# Altium schematic sheet AI驱动.SchDoc
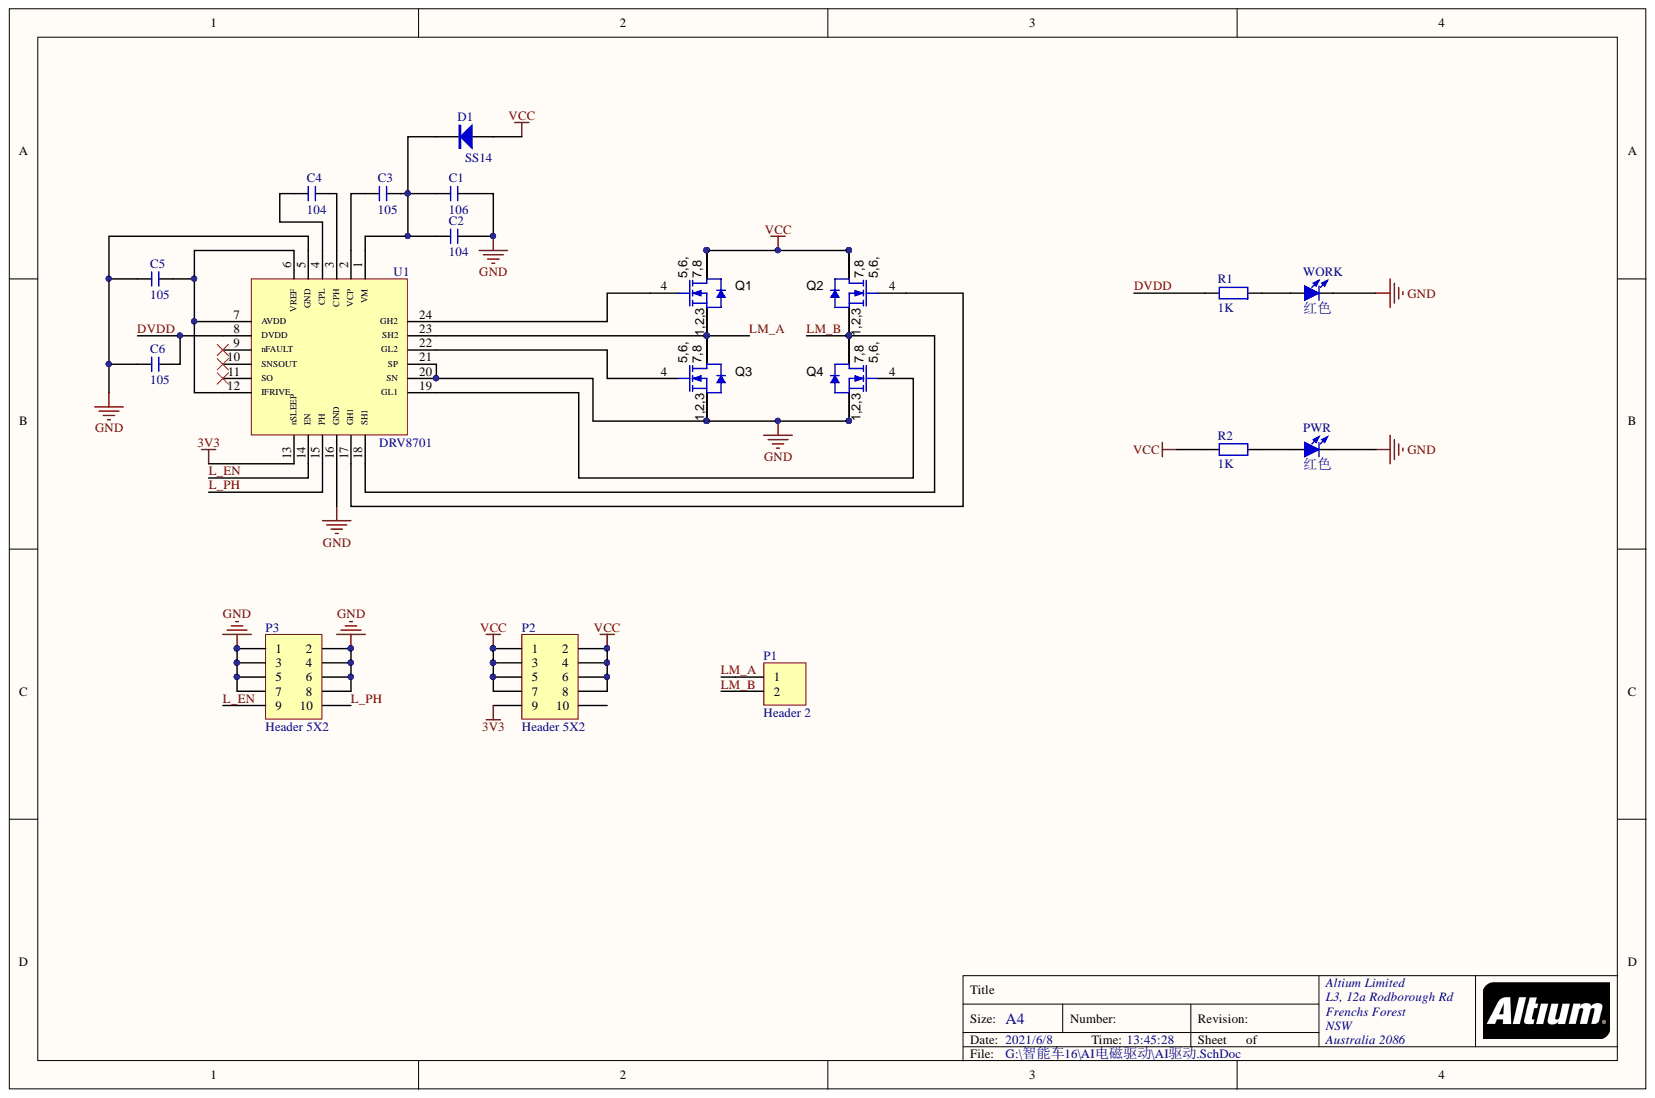
[diagram p0: AI驱动.SchDoc]

## Parts
C1  106  [C 0805_L]
C2  104  [C 0603_L]
C3  105  [C 0603_L]
C4  104  [C 0603_L]
C5  105  [C 0603_L]
C6  105  [C 0603_L]
D1  SS14  [SMA]
P1  Header 2  [KF301-5.0-2P]
P2  Header 5X2  [HDR2X5]
P3  Header 5X2  [HDR2X5]
PWR  红色  [LED 0805G]
Q1  TPH1R403NL/CSD18532Q5B  [TRANS_NexFET_Q5B]  (value not drawn)
Q2  TPH1R403NL/CSD18532Q5B  [TRANS_NexFET_Q5B]  (value not drawn)
Q3  TPH1R403NL/CSD18532Q5B  [TRANS_NexFET_Q5B]  (value not drawn)
Q4  TPH1R403NL/CSD18532Q5B  [TRANS_NexFET_Q5B]  (value not drawn)
R1  1K  [R 0603_L]
R2  1K  [R 0603_L]
U1  DRV8701  [QFN24 4x4_N]
WORK  红色  [LED 0805G]

## Nets
3V3: P2.9 U1.13(nSLEEP)
GND: C1.2 C2.2 C5.1 C6.1 P3.1 P3.2 P3.3 P3.4 P3.5 P3.6 P3.7 P3.8 PWR.2 Q3.1 Q3.2 Q3.3 Q4.1 Q4.2 Q4.3 U1.5(GND) U1.16(GND) U1.20(SN) U1.21(SP) WORK.2
VCC: D1.1 P2.1 P2.2 P2.3 P2.4 P2.5 P2.6 P2.7 P2.8 Q1.5 Q1.6 Q1.7 Q1.8 Q2.5 Q2.6 Q2.7 Q2.8 R2.1
DVDD: C6.2 R1.1 U1.8(DVDD)
L_EN: P3.9 U1.14(EN)
L_PH: P3.10 U1.15(PH)
LM_A: P1.1 Q1.1 Q1.2 Q1.3 Q3.5 Q3.6 Q3.7 Q3.8 U1.23(SH2)
LM_B: P1.2 Q2.1 Q2.2 Q2.3 Q4.5 Q4.6 Q4.7 Q4.8 U1.18(SH1)
NetC1_1: C1.1 C2.1 C3.2 D1.2 U1.1(VM)
NetC3_1: C3.1 U1.2(VCP)
NetC4_1: C4.1 U1.4(CPL)
NetC4_2: C4.2 U1.3(CPH)
NetC5_2: C5.2 U1.6(VREF) U1.7(AVDD) U1.12(IFRIVE)
NetPWR_1: PWR.1 R2.2
NetQ1_4: Q1.4 U1.24(GH2)
NetQ2_4: Q2.4 U1.17(GH1)
NetQ3_4: Q3.4 U1.22(GL2)
NetQ4_4: Q4.4 U1.19(GL1)
NetR1_2: R1.2 WORK.1

Not connected: P2 10; U1 9(nFAULT) 10(SNSOUT) 11(SO)

## Library data of the parts
C1-C6 = C: 无极性贴片电容; 版权归属: 源创客; BOM名称: 无极性贴片电容; Component Kind: Standard; Library Name: 3.1   - 电阻-电容-电感.SchLib; 学习资源: www.ooocke.com
D1 = SS14: 肖特基二极管; 属性: 40V/1A; 版权归属: 源创客; BOM名称: 肖特基二极管; Component Kind: Standard; Library Name: 8.1   - 二极管-整流桥.SchLib; 学习资源: www.ooocke.com
P1 = Header 2: Header, 2-Pin; Component Kind: Standard; LatestRevisionDate: 17-Jul-2002; LatestRevisionNote: Re-released for DXP Platform.; Library Name: Miscellaneous Connectors.IntLib; Signal Integrity: Connector; Publisher: Altium Limited
P2 P3 = Header 5X2: Header, 5-Pin, Dual row; Component Kind: Standard; LatestRevisionDate: 17-Jul-2002; LatestRevisionNote: Re-released for DXP Platform.; Library Name: Miscellaneous Connectors.IntLib; Signal Integrity: Connector; Publisher: Altium Limited
PWR WORK = LED-SMD: 贴片LED; 版权归属: 源创客; BOM名称: 贴片LED; Component Kind: Standard; Library Name: 9.1   - LED.SchLib; 学习资源: www.ooocke.com
Q1-Q4 = CSD18532Q5B: Alternate Manufacturer: None; Manufacturer: Texas Instruments; ChType: N; CompType: MOSFET; IdsMax_A: 172; QgTyp_nC: 27; Rdson45_Ohm: 3.3; U/M: EA; Value: 60V; Value1: 3.3 ohm; Value2: 27 nC; VdsMax_V: 60; Vgs_V: 1.6; Component Kind: Standard; ComponentLink1Description: Datasheet link; ComponentLink1URL: http://www.ti.com/lit/gpn/csd18532q5b; LatestRevisionDate: 2014-05-23; LatestRevisionNote: Added parts.; Library Name: LQ8701.SCHLIB; MountType: SMT; PackageReference: SON 5x6mm; PartNumber: CSD18532Q5B; Published: 2008-06-19; RoHS: Y; Supplier 1: Digi-Key; Supplier 2: Mouser; Supplier 3: Newark; Supplier Part Number 1: 296-35628-1-ND; Supplier Part Number 2: 595-CSD18532Q5B; Supplier Part Number 3: 63W5308; SymbolVersion: 2008-07-09; Publisher: Texas Instruments
R1 R2 = R: 贴片电阻; 版权归属: 源创客; BOM名称: 贴片电阻; Component Kind: Standard; Library Name: 3.1   - 电阻-电容-电感.SchLib; 学习资源: www.ooocke.com
U1 = Component_1: Component Kind: Standard; Library Name: Schlib1.SchLib
